# Altium schematic sheet [14] MISC.SchDoc(BLK4)
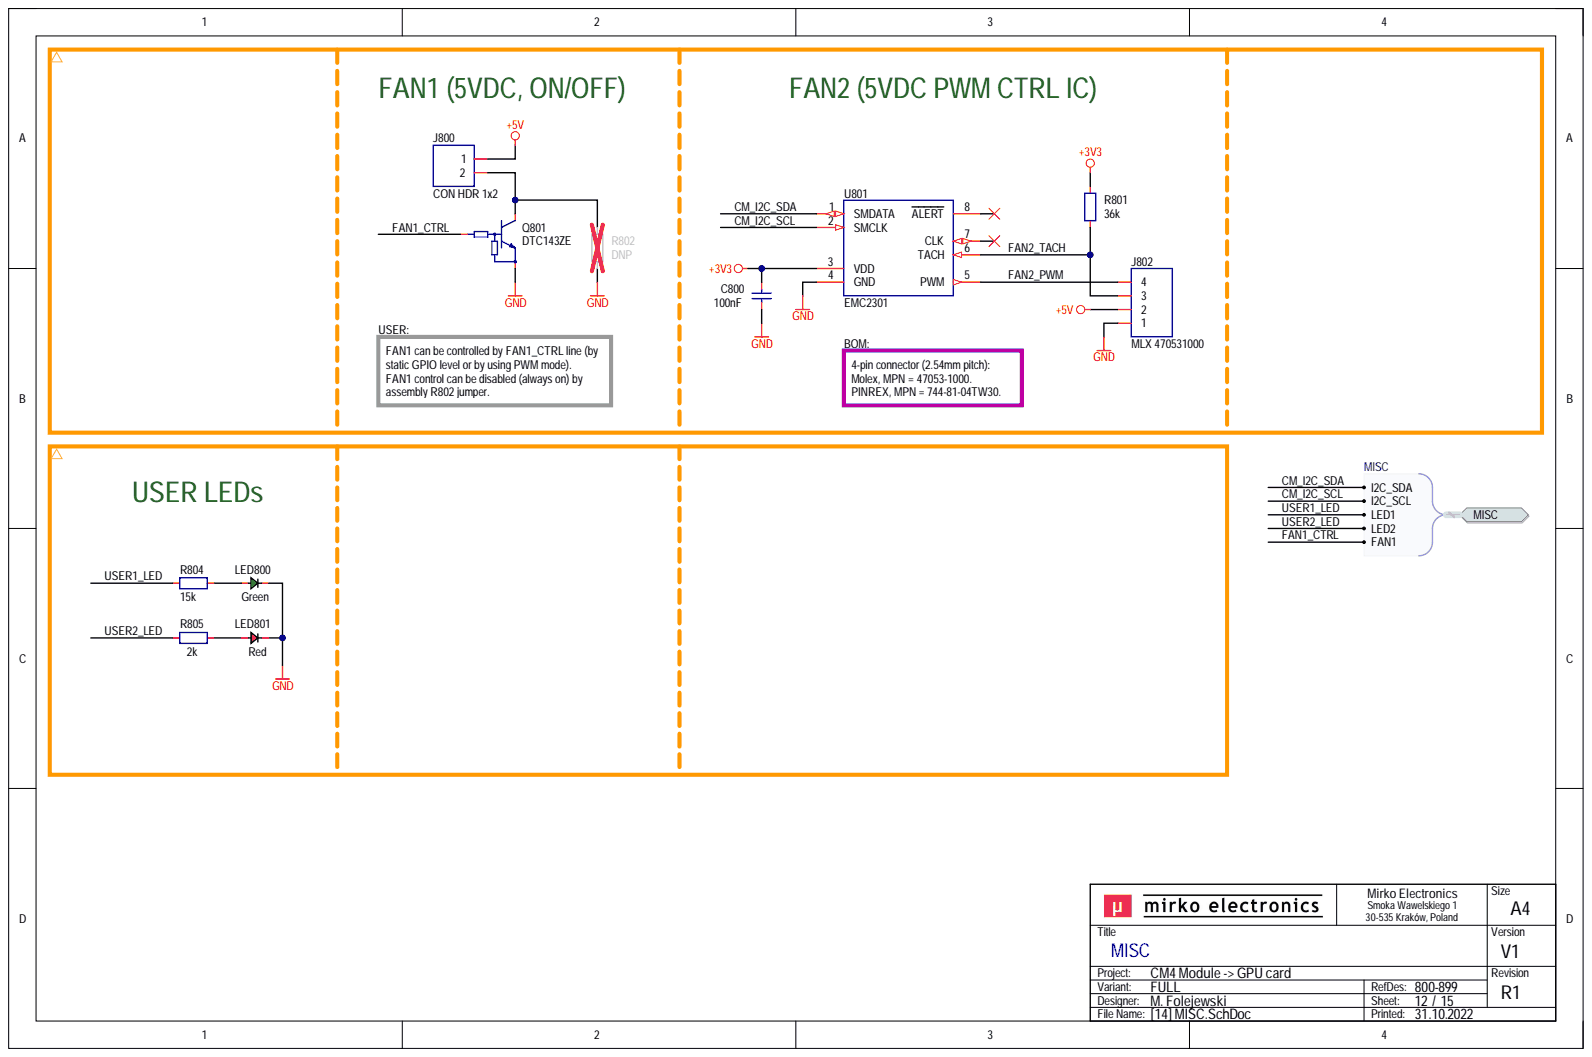
[diagram p0: [14] MISC.SchDoc]

## Parts
C800  100nF  [CAP_0603_R]
J800  CON HDR 1x2  [CON_HDR_P254_1X02_STR]
J802  MLX 470531000  MPN: 470531000  [CON_MLX_470531000]
LED800  Green  [LED_0805_GRN_R]
LED801  Red  [LED_0805_RED_R]
Q801  DTC143ZE  [PKG_SOT523_SC75_SOT416_P100_R]
R801  36k  [RES_0603_R]
R802  DNP  [RES_0603_R]
R804  15k  [RES_0603_R]
R805  2k  [RES_0603_R]
U801  EMC2301  [ICC_TSSOP8_300X300X110P65]

## Ports
MISC, MISC.FAN1, MISC.I2C_SCL, MISC.I2C_SDA, MISC.LED1, MISC.LED2

## Nets
+3V3: C800.2 R801.2 U801.3(VDD)
+5V: J800.1 J802.2
GND: C800.1 J802.1 LED800.1 LED801.1 Q801.2 R802.1 U801.4(GND)
CM_I2C_SCL: U801.2(SMCLK) ; port MISC
CM_I2C_SDA: U801.1(SMDATA) ; port MISC
FAN1_CTRL: Q801.1 ; port MISC
FAN2_PWM: J802.4 U801.5(PWM)
FAN2_TACH: J802.3 R801.1 U801.6(TACH)
USER1_LED: R804.1 ; port MISC
USER2_LED: R805.1 ; port MISC
NetJ800_2: J800.2 Q801.3 R802.2
NetLED800_2: LED800.2 R804.2
NetLED801_2: LED801.2 R805.2

Not connected: U801 7(CLK) 8(ALERT)

## Library data of the parts
C800 = CAP-0008: Capacitor; MLCC; 100nF; TBD; TBD; TBD; TBD; SMD; 0603 (1608);; Database Table Name: CAPACITOR$; Component Kind: Standard; Component Subtype: MLCC; Component Type: Capacitor; Manufacturer: TBD; Mounting Type: SMD; MPN: TBD; Package (Case): 0603 (1608); Supplier: ?; Value: 100nF; Library Name: MirFol_db_local.DbLib
J800 = CON-0020: Connector; Pin Header; 1 x 2 pins; 2.54mm; Male; TBD; TBD; THT; THT;; Manufacturer: GENERIC; Database Table Name: CONNECTOR$; Component Kind: Standard; Component Subtype: Pin Header; Component Type: Connector; Mounting Type: THT; MPN: GENERIC; Package (Case): THT; Supplier: ?; Value: CON HDR 1x2; Library Name: MirFol_db_local.DbLib
J802 = CON_1X04_COL: Connector; 1 Row;; Manufacturer: Molex; Component Subtype: Pin header; Package (Case): THT; Component Kind: Standard; Component Type: Connector; Mounting Type: THT; Value: MLX 470531000; Library Name: MirFol_lib.SchLib
LED800 = DIO_LED_GRN: Diode; LED; Green;; Manufacturer: Foshan NationStar Optoelectronics; MPN: NCD0805G1; Component Subtype: LED Diode; Package (Case): 0805 (2012); Component Kind: Standard; Component Type: LED; Mounting Type: SMD; Supplier: LCSC; Value: Green; Library Name: MirFol_lib.SchLib
LED801 = DIO_LED_RED: Diode; LED; Red;; Manufacturer: Foshan NationStar Optoelectronics; MPN: NCD0805R1; Component Subtype: LED Diode; Package (Case): 0805 (2012); Component Kind: Standard; Component Type: LED; Mounting Type: SMD; Supplier: LCSC; Value: Red; Library Name: MirFol_lib.SchLib
Q801 = TRA_BJT_NPN_DIG_BEC: Transistor; BJT; NPN; Digital;; Component Subtype: NPN Transistor; Component Kind: Standard; Component Type: Transistor; Mounting Type: SMD; MPN: DTC143ZE; Supplier: ?; Value: DTC143ZE; Library Name: MirFol_lib.SchLib
R801 R802 = RES-0054: Resistor; Thick Film; GENERIC; TBD; TBD; TBD; TBD; TBD; SMD; 0603 (1608);; Database Table Name: RESISTOR$; Component Kind: Standard; Component Subtype: Thick Film; Component Type: Resistor; Manufacturer: GENERIC; Mounting Type: SMD; MPN: GENERIC; Package (Case): 0603 (1608); Supplier: ?; Library Name: MirFol_db_local.DbLib
  R801: Value: 36k
  R802: Value: DNP
R804 = RES-0043: Resistor; Thick Film; 15k; 1%; 100mW; 100PPM; 75V; Standard; SMD; 0603 (1608);; Manufacturer: Yageo Corporation; MPN: TBD; Database Table Name: RESISTOR$; Component Kind: Standard; Component Subtype: Thick Film; Component Type: Resistor; Mounting Type: SMD; Package (Case): 0603 (1608); Supplier: ?; Value: 15k; Library Name: MirFol_db_local.DbLib
R805 = RES-0033: Resistor; Thick Film; 2k2; 1%; 100mW; 100PPM; 75V; Standard; SMD; 0603 (1608);; Manufacturer: Yageo Corporation; MPN: TBD; Database Table Name: RESISTOR$; Component Kind: Standard; Component Subtype: Thick Film; Component Type: Resistor; Mounting Type: SMD; Package (Case): 0603 (1608); Supplier: ?; Value: 2k; Library Name: MirFol_db_local.DbLib
U801 = ICC_MCP_EMC2301_MSOP-8: IC; Fan controller;; Manufacturer: Microchip Technology; MPN: EMC2301-1-ACZL-TR; Package (Case): MSOP-8; Component Kind: Standard; Component Subtype: Fan controller; Component Type: IC; Mounting Type: SMD; Value: EMC2301; Library Name: MirFol_lib.SchLib
